# Altium schematic sheet Cell_Group_Test.SchDoc
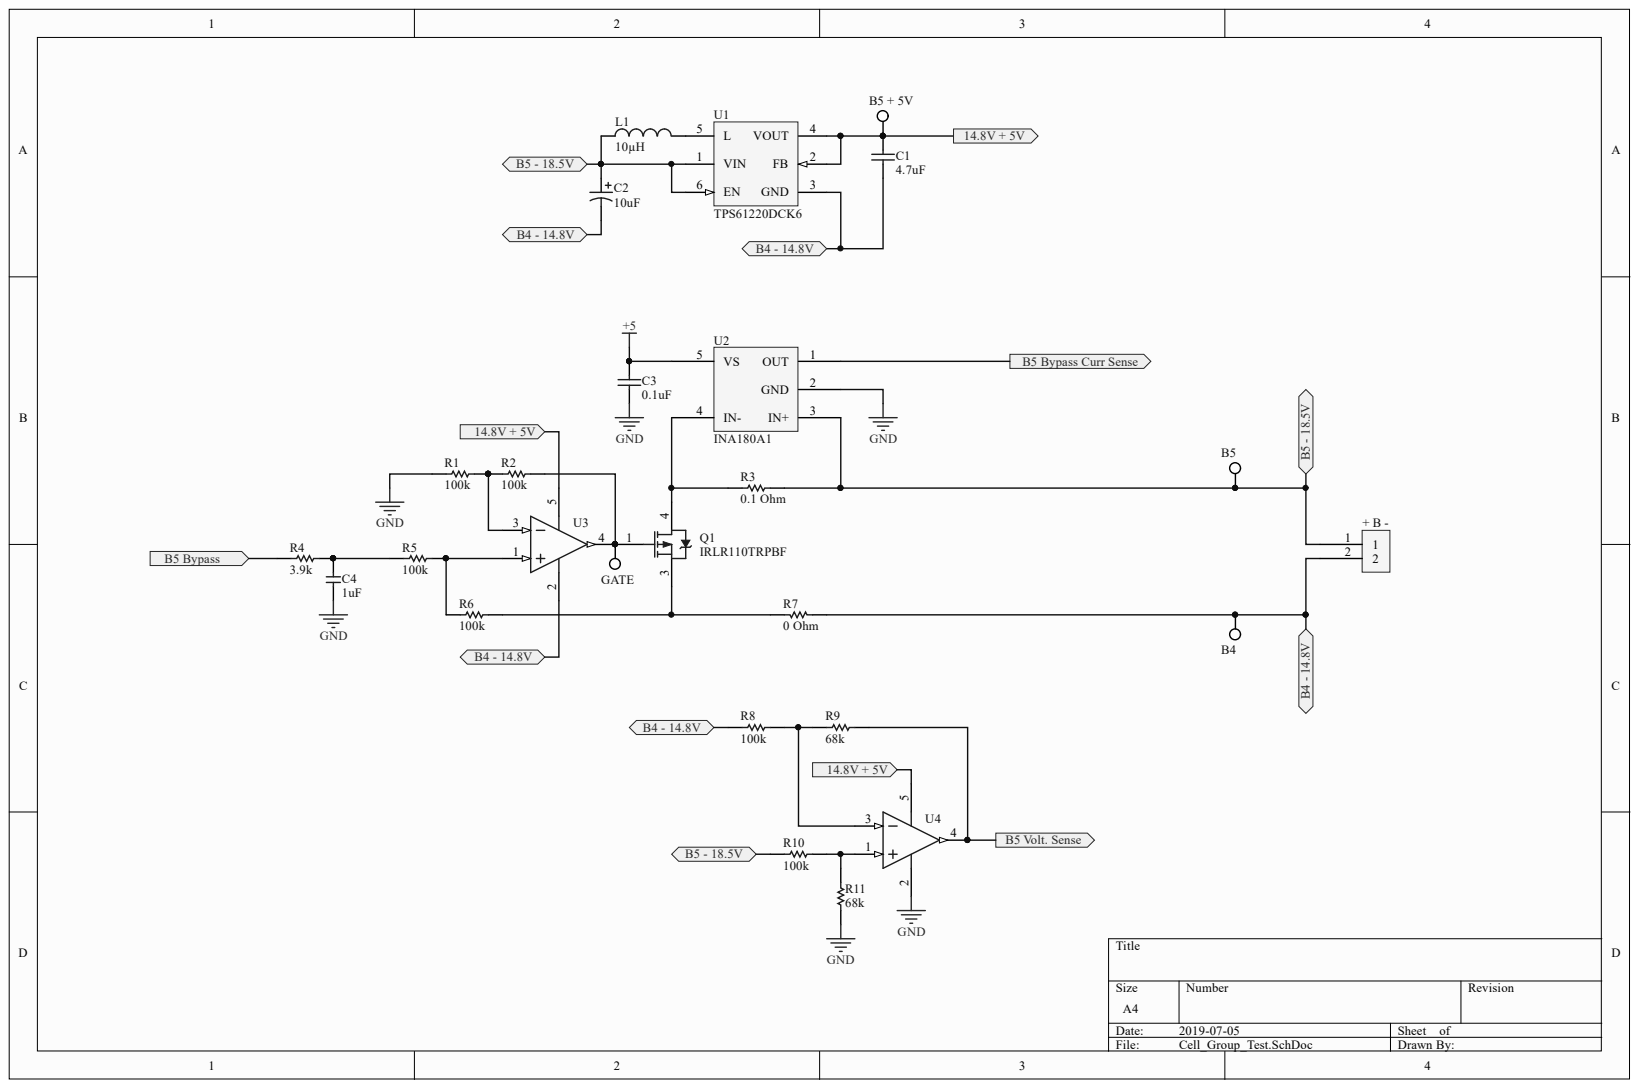
[diagram p0: Cell_Group_Test.SchDoc]

## Parts
+_B_-  Würth Elektronik 691137710002  [PCB-g2bho722h4u85oltew9j-1]  (value not drawn)
B4  Keystone Electronics 5002  [PCB-6rf74k5lqbtmzvabbodp-1]  (value not drawn)
B5  BYPASS  [PCB-6rf74k5lqbtmzvabbodp-1]  (value not drawn)
B5_+_5V  BYPASS  [PCB-6rf74k5lqbtmzvabbodp-1]  (value not drawn)
C1  4.7uF  [PCB-aplbgutxmqgu2769pozc-1]
C2  10uF  [3mm Dia SMD Cap]
C3  0.1uF  [PCB-fvggjhlky4an5us2gvhu-1]
C4  1uF  [PCB-byo5il7f8wp7b7f3c12u-1]
GATE  Keystone Electronics 5002  [PCB-6rf74k5lqbtmzvabbodp-1]  (value not drawn)
L1  10µH  [PCB-vewda3j484q4i4ft994d-1]
Q1  SYM-837447-1  [PCB-5orkzbd4xoofe4n7g14x-1]  (value not drawn)
R1  100k  [RESC1608X55X25ML10T15]
R2  100k  [RESC1608X55X25ML10T15]
R3  0.1 Ohm  [RESC3216X89X51NL25T25]
R4  3.9k  [RESC1608X55X30ML15T15]
R5  100k  [RESC1608X55X25ML10T15]
R6  100k  [RESC1608X55X25ML10T15]
R7  0 Ohm  [RESC3225X60X45LL20T20]
R8  100k  [RESC1608X55X25ML10T15]
R9  68k  [RESC1608X55X25LL10T15]
R10  100k  [RESC1608X55X25ML10T15]
R11  68k  [RESC1608X55X25LL10T15]
U1  TPS61220DCK6  [PCB-cf8mw4cww6fq2gaq6ccu-1]
U2  INA180A1  [SOT23-5_D]
U3  CMP-0055-01337-3  [MF05A_L]  (value not drawn)
U4  CMP-0055-01337-3  [MF05A_L]  (value not drawn)

## Ports
14.8V + 5V, B4 - 14.8V, B5 - 18.5V, B5 BYPASS, B5 BYPASS CURR SENSE, B5 VOLT. SENSE

## Nets
+5: C3.1 U2.5(VS)
GND: C3.2 C4.2 R1.1 R11.1 U2.2(GND) U4.2
14.8V + 5V: B5_+_5V.TP C1.1 U1.2(FB) U1.4(VOUT) U3.5 U4.5 ; port 14.8V + 5V
B4 - 14.8V: +_B_-.2 B4.TP C1.2 C2.2 R7.1 R8.1 U1.3(GND) U3.2 ; port B4 - 14.8V
B5 - 18.5V: +_B_-.1 B5.TP C2.1 L1.1 R3.2 R10.1 U1.1(VIN) U1.6(EN) U2.3(IN+) ; port B5 - 18.5V
NetC4_1: C4.1 R4.1 R5.1
NetGATE_TP: GATE.TP Q1.1 R2.2 U3.4
NetL1_2: L1.2 U1.5(L)
NetQ1_3: Q1.3 R6.2 R7.2
NetQ1_4: Q1.4 R3.1 U2.4(IN-)
NetR1_2: R1.2 R2.1 U3.3
NetR4_2: R4.2 ; port B5 BYPASS
NetR5_2: R5.2 R6.1 U3.1
NetR8_2: R8.2 R9.2 U4.3
NetR9_1: R9.1 U4.4 ; port B5 VOLT. SENSE
NetR10_2: R10.2 R11.2 U4.1
NetU2_1: U2.1(OUT) ; port B5 BYPASS CURR SENSE

## Library data of the parts
+_B_- = 691137710002: Series 1377 - 5.00 mm Horizontal Entry with Pressure Clamp WR-TBL, 2 pin; Pin Pitch: 5.00 mm; Component Kind: Standard; Library Name: Octopart library; Manufacturer Part Number: 691137710002; Mounting Style: Through Hole; Number of Pins: 2; Octopart URL: https://octopart.com/691137710002-w%C3%BCrth+elektronik-78871135; Operating Temperature: 120 °C (max)
B4 B5 B5_+_5V GATE = 5002: KEYSTONE   5002   Series:-; Lead-Free Status: Lead Free; Color: White; Component Kind: Standard; Contact Material: Phosphor Bronze; Contact Plating: Tin; Library Name: Octopart library; Manufacturer: Keystone; Manufacturer Part Number: 5002; OctopartID: f34a3a40c2652c8d; Octopart Url: https://octopart.com/5002-keystone-5193; Packaging: Bag; REACH SVHC Compliance: No SVHC; RoHS: Compliant; Size-Diameter: 2.54 mm; Size-Height: 7.62 mm; Size-Inner Diameter: 1.27 mm; Size-Length: 7.62 mm
C1 = JMK107BJ475KA-T: Cap Ceramic 4.7uF 6.3V X5R 10% SMD 0603 85°C Paper T/R; Capacitance: 4.7e-06 F; Lead-Free Status: Lead Free; Capacitance Tolerance: ±10%; Case/Package: 0603; Component Kind: Standard; Dielectric Characteristic: X5R; Halogen Free Status: Halogen Free; Library Name: Octopart library; Lifecycle Status: Active; Manufacturer Part Number: JMK107BJ475KA-T; Mounting Style: Surface Mount; Number of Pins: 2; OctopartID: 5aee93ec96de8529; Octopart URL: http://octopart.com/jmk107bj475ka-t-taiyo+yuden-584022; Packaging: Cut Tape (CT); RoHS: Compliant; Size-Height: 0.0008 m; Size-Length: 0.0016 m; Size-Width: 0.0008 m; Voltage Rating (DC): 6.3 V
C2 = UWX1C100MCL2GB: WX Series 10 uF 16 V 85°C Ø3 x 5.4 mm SMT Chip Aluminum Electrolytic Cap; Capacitance: 10.0 µF; Capacitance Tolerance: ±20%; Lead-Free Status: Lead Free; Voltage Rating (DC): 16.0 V; Component Kind: Standard; Dielectric Material: Aluminum Electrolytic; Library Name: Octopart library; Manufacturer Part Number: UWX1C100MCL2GB; Mounting Style: Surface Mount; Number of Pins: 2; OctopartID: 1b26d22f2722c4e1; Octopart URL: http://octopart.com/uwx1c100mcl2gb-nichicon-203106; Packaging: Cut Tape (CT); REACH SVHC Compliance: No SVHC; RoHS: Compliant; Size-Diameter: 3.00 mm; Size-Height: 5.40 mm; Size-Length: 5.40 mm
C3 = CC0603KRX7R8BB104: 0603 0.1 uF 25 V ±10 % Tolerance X7R SMT Multilayer Ceramic Capacitor; Component Kind: Standard; Halogen Free Status: Halogen Free; Library Name: Octopart library; OctopartID: 56da9fafa36a891d
C4 = UMK212BJ105KG-T: Cap Ceramic 1uF 50V X5R 10% SMD 0805 85°C Embossed T/R; Capacitance: 1.00 µF; Voltage Rating (DC): 50.0 V; Capacitance Tolerance: ±10%; Case/Package: 0805, 2012; Component Kind: Standard; Dielectric Characteristic: X5R; Halogen Free Status: Halogen Free; Library Name: Octopart library; Lifecycle Status: Active; Manufacturer Part Number: UMK212BJ105KG-T; Mounting Style: Surface Mount; Number of Pins: 2; OctopartID: 82924b3825e75d55; Octopart URL: http://octopart.com/umk212bj105kg-t-taiyo+yuden-10519059; Packaging: Cut Tape (CT); RoHS: Compliant
L1 = LQH3NPN100MJRL: Inductor Power Chip Shielded Wirewound 10uH 20% 1MHz Ferrite 1.2A 0.288Ohm DCR 1212 T/R; Component Kind: Standard; Library Name: Octopart library
Q1 = IRFR5305TRPBF: MOSFET P-CH 55V 31A DPAK; Component Kind: Standard; Library Name: Octopart library
R1 R2 R5 R6 R8 R10 = RT0603BRD07100KL: Res Thin Film 0603 100K Ohm 0.1% 1/10W 25ppm/C Molded SMD SMD Paper T/R; Lead-Free Status: Lead Free; Power Rating: 0.1 W; Resistance: 100000.0 Ω; Voltage Rating (DC): 75.0 V; Case/Package: 0603; Component Kind: Standard; Library Name: Octopart library; Manufacturer Part Number: RT0603BRD07100KL; Mounting Style: Surface Mount; OctopartID: 1de8d4f75e52c22f; Octopart Url: https://octopart.com/rt0603brd07100kl-yageo-1402588; Resistance Tolerance: ±0.1%; RoHS: Compliant; Size-Height: 0.00045 m; Size-Length: 0.0016 m; Size-Width: 0.0008 m
R3 = WSL1206R1000FEA: 100 mOhms ±1% 0.25W, 1/4W Chip Resistor 1206 (3216 Metric) Automotive AEC-Q200, Current Sense, Moisture Resistant, Pulse Withstanding Metal Element; Case/Package (SI): 3216; Lead-Free Status: Lead Free; Resistance: 0.1 Ω; Temperature Coefficient: 75.0 ppm/°C; Case/Package: 1206; Component Kind: Standard; Library Name: Octopart library; Manufacturer Part Number: WSL1206R1000FEA; Mounting Style: Surface Mount; Number of Pins: 2; OctopartID: 598e92da0fea8649; Octopart Url: https://octopart.com/wsl1206r1000fea-vishay-39744258; Power Rating: 0.25 W; REACH SVHC Compliance: No SVHC; Resistance Tolerance: ±1%; RoHS: Compliant; Size-Height: 0.000635 m; Size-Length: 0.0032004 m; Size-Width: 0.0016002 m
R4 = ERJ-3GEYJ392V: Thick Film Resistors - SMD 0603 3.9Kohms 5% Tol; Lead-Free Status: Lead Free; Power Rating: 0.1 W; Resistance: 3900.0 Ω; Voltage Rating (DC): 75.0 V; Case/Package: 0603; Component Kind: Standard; Library Name: Octopart library; Lifecycle Status: Not Listed by Manufacturer; Manufacturer Part Number: ERJ-3GEYJ392V; Mounting Style: Surface Mount; OctopartID: 2d5de71aa4aaf29a; Octopart Url: https://octopart.com/erj-3geyj392v-panasonic-55422097; Packaging: Cut Tape (CT); REACH SVHC Compliance: No SVHC; Resistance Tolerance: ±5%; RoHS: Compliant; Size-Height: 0.00045 m; Size-Length: 0.0016 m; Size-Width: 0.0008 m
R7 = CRCW12100000Z0EAHP: Thick Film Resistors - SMD 3/4watt ZERO ohm Jumper; Lead-Free Status: Lead Free; Power Rating: 0.5 W; Resistance: 0.0 Ω; Case/Package: 1210; Component Kind: Standard; Library Name: Octopart library; Manufacturer Part Number: CRCW12100000Z0EAHP; OctopartID: 9eca5567ff3f410d; Octopart Url: https://octopart.com/crcw12100000z0eahp-vishay+dale-44516499; RoHS: Compliant
R9 R11 = RT0603BRD0768KL: Thin Film Resistors - SMD 1/10W 68K ohm .1% 25ppm; Lead-Free Status: Lead Free; Power Rating: 0.1 W; Resistance: 68000.0 Ω; Resistance Tolerance: ±0.1%; Voltage Rating (DC): 50.0 V; Case/Package: 0603; Component Kind: Standard; Library Name: Octopart library; Manufacturer Part Number: RT0603BRD0768KL; Mounting Style: Surface Mount; OctopartID: 4fbd86a45dce907a; Octopart Url: https://octopart.com/rt0603brd0768kl-yageo-1259285; RoHS: Compliant; Size-Height: 0.00045 m; Size-Length: 0.0016 m; Size-Width: 0.0008 m
U1 = TPS61220DCKR: Boost Voltage Converter with 0.7 to 5.5 V Input and 1.8 to 5.5 V Output, -40 to 85 degC, 6-Pin SC70 (DCK), Green (RoHS & no Sb/Br); Number of Regulated Outputs: 1; Output Current: 0.2 A; Quiescent Current: 5e-06 A; Component Kind: Standard; Library Name: Octopart library; Lifecycle Status: Active; Manufacturer Part Number: TPS61220DCKR; OctopartID: bc5c75e3c544639b; Packaging: Cut Tape (CT); RoHS: Compliant
U2 = INA180A1IDBVR: 26V, Low- or High-Side Voltage Output Current Sense Amplifier 5-SOT-23 -40 to 125; Lead-Free Status: Lead Free; Component Kind: Standard; Library Name: Octopart library; Manufacturer Part Number: INA180A1IDBVR; OctopartID: 29d7e410373ef610; Octopart URL: http://octopart.com/ina180a1idbvr-texas+instruments-80558991; RoHS: Compliant
U3 U4 = CMP-0055-01337-3: Low Power Single Op Amp, 5-pin SOT-23; Manufacturer Part Number: LM321MFX; Gain Bandwidth Product: 1000000.0 Hz; Input Offset Drift: 7e-06 V/K; Lead-Free Status: Contains Lead; Number of Channels: 1; Output Current: 0.02 A; Slew Rate: 0.5 V/μs; Case/Package: SOT-23; Component Kind: Standard; Library Name: CommunityVault; Lifecycle Status: Active; Number of Pins: 5; OctopartID: a48b6cfa843d7b4c; Octopart Url: https://octopart.com/lm321mfx-texas+instruments-24839632; Packaging: Reel; RoHS: Non-Compliant
